# Altium schematic sheet 电源.SchDoc(电源)
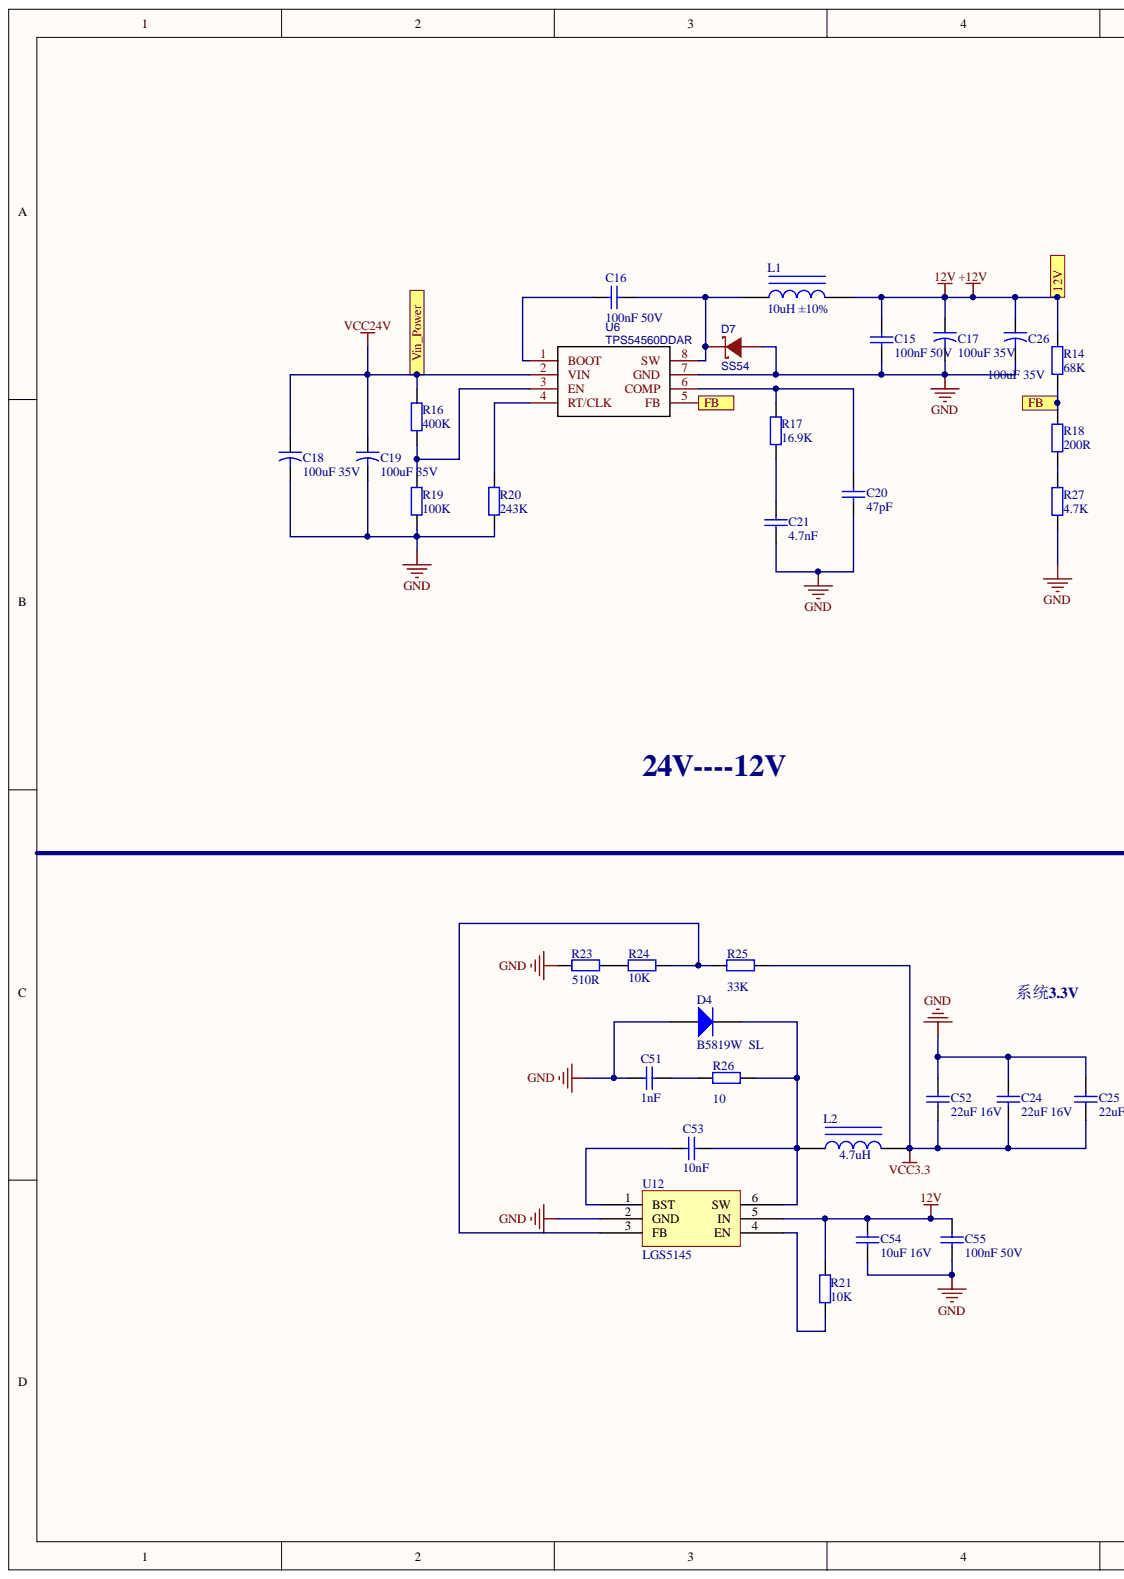
[diagram p0: 电源.SchDoc, left]
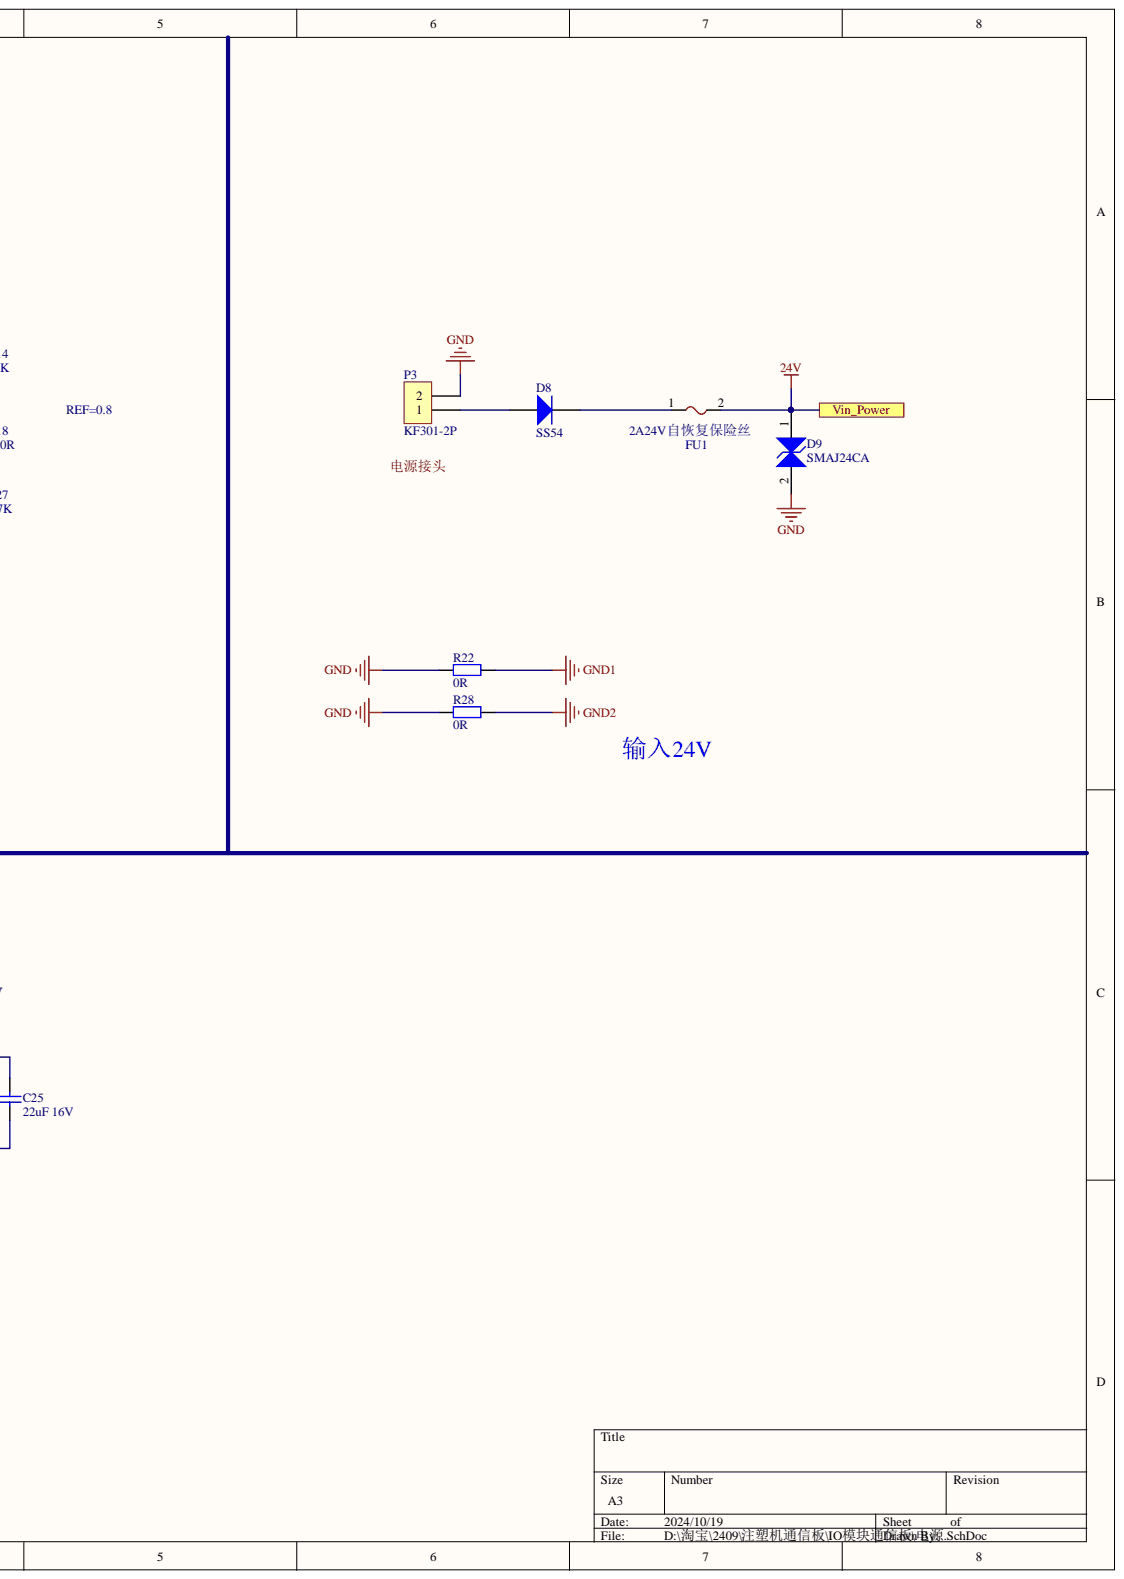
[diagram p1: 电源.SchDoc, right]

## Parts
C15  100nF 50V  [0603_C]
C16  100nF 50V  [0603_C]
C17  100uF 35V  [CAP-SMD_BD6.3-L6.6-W6.6-FD]
C18  100uF 35V  [CAP-SMD_BD6.3-L6.6-W6.6-FD]
C19  100uF 35V  [CAP-SMD_BD6.3-L6.6-W6.6-FD]
C20  47pF  [0603_C]
C21  4.7nF  [0603_C]
C24  22uF 16V  [0805_C]
C25  22uF 16V  [0805_C]
C26  100uF 35V  [CAP-SMD_BD6.3-L6.6-W6.6-FD]
C51  1nF  [0603_C]
C52  22uF 16V  [0805_C]
C53  10nF  [0603_C]
C54  10uF 16V  [0805_C]
C55  100nF 50V  [0603_C]
D4  B5819W  SL  [SOD-123]
D7  SS54  [SMA(DO-214AC)_S1]
D8  SS54  [SMA(DO-214AC)_S1]
D9  SMAJ24CA  [SMA(DO-214AC)_S1]
FU1  2A24V自恢复保险丝  [1812_R]
L1  10uH ±10%  [SLF12565]
L2  4.7uH  [RLF7030]
P3  KF301-2P  [3.81-2P -90]
R14  68K  [0603_R]
R16  400K  [0603_R]
R17  16.9K  [0603_R]
R18  200R  [0603_R]
R19  100K  [0603_R]
R20  243K  [0603_R]
R21  10K  [0603_R]
R22  0R  [0603_R]
R23  510R  [0603_R]
R24  10K  [0603_R]
R25  33K  [0603_R]
R26  10  [0603_R]
R27  4.7K  [0603_R]
R28  0R  [0603_R]
U6  TPS54560DDAR  [SOIC-8_EP_150mil]
U12  LGS5145  [SOT-23-6]

## Ports
12V, FB, Vin_Power

## Nets
GND: C15.1 C17.2 C18.2 C19.2 C20.1 C21.2 C24.2 C25.2 C26.2 C51.1 C52.2 C54.1 C55.1 D4.1 D7.1 D9.2 P3.2 R19.1 R20.1 R22.2 R23.1 R27.1 R28.2 U6.7(GND) U12.2(GND)
GND1: R22.1
GND2: R28.1
VCC3.3: C24.1 C25.1 C52.1 L2.2 R25.2
24V: C18.1 C19.1 D9.1 FU1.2 R16.2 U6.2(VIN) ; port Vin_Power
+12V: C15.2 C17.1 C26.1 C54.2 C55.2 L1.2 R14.2 R21.2 U12.5(IN) ; port 12V
FB: R14.1 R18.2 U6.5(FB) ; port FB
NetC16_1: C16.1 D7.2 L1.1 U6.8(SW)
NetC16_2: C16.2 U6.1(BOOT)
NetC20_2: C20.2 R17.2 U6.6(COMP)
NetC21_1: C21.1 R17.1
NetC51_2: C51.2 R26.2
NetC53_1: C53.1 U12.1(BST)
NetC53_2: C53.2 D4.2 L2.1 R26.1 U12.6(SW)
NetD8_1: D8.1 P3.1
NetD8_2: D8.2 FU1.1
NetR16_1: R16.1 R19.2 U6.3(EN)
NetR18_1: R18.1 R27.2
NetR20_2: R20.2 U6.4(RT/CLK)
NetR21_1: R21.1 U12.4(EN)
NetR23_2: R23.2 R24.1
NetR24_2: R24.2 R25.1 U12.3(FB)
电源接头:

## Library data of the parts
C15 C55 = 0402 100nF (104) 10% 16V: 贴片电容; SuppliersPartNumber: C1525; Supplier: LC; Package: 0402; Library Name: Cap.SchLib; ComponentLink1URL: http://www.szlcsc.com/product/details_1877.html; ComponentLink1Description: 供应商链接; Component Kind: Standard
C16 C20 C21 C54 = 0805 10uF (106) 10% 25V: 贴片电容; SuppliersPartNumber: C15850; Supplier: LC; Package: 0805; Library Name: Cap.SchLib; ComponentLink1URL: http://www.szlcsc.com/product/details_16532.html; ComponentLink1Description: 供应商链接; Component Kind: Standard
C17-C19 C26 = Cap2: Capacitor; Simulation: CAP; Signal Integrity: Cap; Published: 23-Sep-2002; PackageReference: CAPR5-4X5; PackageDescription: Capacitor; 2 Leads; Library Name: Miscellaneous Devices.IntLib; Component Kind: Standard; Publisher: Altium Limited
C24 C25 C52 = 0805 22uF (226) 20% 25V: 贴片电容; SuppliersPartNumber: C45783; Supplier: LC; Package: 0805; Library Name: Cap.SchLib; ComponentLink1URL: http://www.szlcsc.com/product/details_46786.html; ComponentLink1Description: 供应商链接; Component Kind: Standard
C51 = 0402 1nF (102) 10% 50V: 贴片电容; SuppliersPartNumber: C1523; Supplier: LC; Package: 0402; Library Name: Cap.SchLib; ComponentLink1URL: http://www.szlcsc.com/product/details_1875.html; ComponentLink1Description: 供应商链接; Component Kind: Standard
C53 = 0402 10nF (103) 10% 50V: 贴片电容; SuppliersPartNumber: C15195; Supplier: LC; Package: 0402; Library Name: Cap.SchLib; ComponentLink1URL: http://www.szlcsc.com/product/details_15869.html; ComponentLink1Description: 供应商链接; Component Kind: Standard
D4 D8 = SOD-123 B5819W  SL: 肖特基二极管; SuppliersPartNumber: C8598; Supplier: LC; Package: SOD-123; Library Name: Diode.SchLib; ComponentLink1URL: http://www.szlcsc.com/product/details_9093.html; ComponentLink1Description: 供应商链接; Component Kind: Standard
D7 = (no symbol name): BOM_Manufacturer: MDD; spicePre: D; image: //image.lceda.cn/szlcsc/C22452.jpg; Component Kind: Standard; BOM_Supplier Part: C22452; BOM_Supplier: LCSC; BOM_Manufacturer Part: SS54; BOM_LCSC Assembly: Yes
D9 = SMB/DO-214AA P6SMB6.8CA: TVS; SuppliersPartNumber: C78395; Supplier: LC; Package: SMB/DO-214AA; Library Name: Diode.SchLib; ComponentLink1URL: http://www.szlcsc.com/product/details_79530.html; ComponentLink1Description: 供应商链接; Component Kind: Standard
FU1 = 自恢复保险丝: Component Kind: Standard; Library Name: wgh元件库.SCHLIB
L1 L2 = 1206 10uH 10%: 贴片电感; SuppliersPartNumber: C1051; Supplier: LC; Package: 1206; Library Name: IUD.SchLib; ComponentLink1URL: http://www.szlcsc.com/product/details_1403.html; ComponentLink1Description: 供应商链接; Component Kind: Standard
P3 = CON2: Component Kind: Standard; Library Name: wgh元件库.SCHLIB
R14 R16-R20 R22 R26-R28 = 0805 200KΩ (2003) 1%: 贴片电阻; SuppliersPartNumber: C17539; Supplier: LC; Package: 0805; Library Name: RES.SchLib; ComponentLink1URL: http://www.szlcsc.com/product/details_18227.html; ComponentLink1Description: 供应商链接; Component Kind: Standard
R21 = 0402 200KΩ (2003) 1%: 贴片电阻; SuppliersPartNumber: C25764; Supplier: LC; Package: 0402; Library Name: RES.SchLib; ComponentLink1URL: http://www.szlcsc.com/product/details_26507.html; ComponentLink1Description: 供应商链接; Component Kind: Standard
R23 = 0402 300Ω (3000) 1%: 贴片电阻; SuppliersPartNumber: C25102; Supplier: LC; Package: 0402; Library Name: RES.SchLib; ComponentLink1URL: http://www.szlcsc.com/product/details_25845.html; ComponentLink1Description: 供应商链接; Component Kind: Standard
R24 = 0402 7.5KΩ (7501) 1%: 贴片电阻; SuppliersPartNumber: C25918; Supplier: LC; Package: 0402; Library Name: RES.SchLib; ComponentLink1URL: http://www.szlcsc.com/product/details_26661.html; ComponentLink1Description: 供应商链接; Component Kind: Standard
R25 = 0402 49.9KΩ (4992) 1%: 贴片电阻; SuppliersPartNumber: C25897; Supplier: LC; Package: 0402; Library Name: RES.SchLib; ComponentLink1URL: http://www.szlcsc.com/product/details_26640.html; ComponentLink1Description: 供应商链接; Component Kind: Standard
U6 = (no symbol name): BOM_Manufacturer: TI; spicePre: U; image: //image.lceda.cn/szlcsc/C31966.jpg; Component Kind: Standard; BOM_Supplier Part: C31966; BOM_Supplier: LCSC; BOM_Manufacturer Part: TPS54560DDAR
U12 = MP2359DJ-LF-Z_[C14259]: DC-DC芯片; SuppliersPartNumber: C14259; Supplier: LC; Package: SOT-23-6; Library Name: IC.SchLib; ComponentLink1URL: http://www.szlcsc.com/product/details_14917.html; ComponentLink1Description: 供应商链接; Component Kind: Standard
